# Altium schematic sheet [03] - 28PIN_SCHEMATIC.SchDoc("[03] - 28PIN_SCHEMATIC") (continued)
## Library data of the parts (continued)
R11 R12 = RC0805FR-071KL: SMD Chip Resistor, 1 kOhm, ± 1%, 125 mW, 0805 [2012 Metric], Thick Film, General Purpose; Manufacturer: Yageo; Max Power Dissipation: 125mW; Temperature Coefficient: 100ppm/°C; Value: 1kR; Voltage Rating: 150V; Case Code (Imperial): 0805; Case Code (Metric): 2012; Case/Package: 0805; Category: Chip SMD Resistors; Component Kind: Standard; ComponentLink1Description: https://datasheet.ciiva.com/7941/1686128-7941719.pdf?src-supplier=Element14; ComponentLink1URL: https://datasheet.ciiva.com/7941/1686128-7941719.pdf?src-supplier=Element14; ComponentLink2Description: https://datasheet.ciiva.com/46385/444164-46385020.pdf?src-supplier=Element14; ComponentLink2URL: https://datasheet.ciiva.com/46385/444164-46385020.pdf?src-supplier=Element14; ComponentLink3Description: https://datasheet.ciiva.com/2456/pyu-r-int-thick-7-2456976.pdf?src-supplier=Digikey; ComponentLink3URL: https://datasheet.ciiva.com/2456/pyu-r-int-thick-7-2456976.pdf?src-supplier=Digikey; ComponentLink4Description: https://datasheet.ciiva.com/2456/pyu-r-int-thick-7-2456977.pdf?src-supplier=Digikey; ComponentLink4URL: https://datasheet.ciiva.com/2456/pyu-r-int-thick-7-2456977.pdf?src-supplier=Digikey; ComponentLink5Description: https://datasheet.ciiva.com/2456/pyu-r-int-thick-7-2456975.pdf?src-supplier=Digikey; ComponentLink5URL: https://datasheet.ciiva.com/2456/pyu-r-int-thick-7-2456975.pdf?src-supplier=Digikey; ComponentLink6Description: https://datasheet.ciiva.com/16850/rc0805-16850409.pdf?src-supplier=Future+Electronics; ComponentLink6URL: https://datasheet.ciiva.com/16850/rc0805-16850409.pdf?src-supplier=Future+Electronics; Construction: Rectangular; Depth: 1.25mm; Features: Moisture Resistant; Height: 0.024inch; Lead Free: 5697LeadFree; Length: 0.079inch; Library Name: ATMEGA328P-PU.SchLib; Manufacturer Part Number: RC0805FR-071KL; Max Operating Temperature: 155°C; Military Standard: Not; Min Operating Temperature: -55°C; Number of Terminations: 2; Package Quantity: 1; Packaging: Tape and Reel; Pins: 2; Power Rating: 125mW; Pricing 1: 1=0.1, 10=0.025, 50=0.016, 100=0.0133, 500=0.00912, 1000=0.00787 (USD); Reach SVHC Compliant: No; Resistance Tolerance: 1%; Resistor Type: Thick Film; RoHS: Yes; RoHS Compliant: Yes; Series: RC; Stock 1: 3052484; Supplier 1: Digikey; Supplier Part Number 1: 311-1.00KCRCT-ND; Termination: SMD/SMT; Tolerance: 1%; Voltage Rating (AC): 150V; Voltage Rating (DC): 150V; Width: 0.049inch
R13 = R1K: SMD Chip Resistor, 1 kOhm, ± 1%, 125 mW, 0805 [2012 Metric], Thick Film, General Purpose; Manufacturer: Yageo; Manufacturer Part Number: RC0805FR-071KL; Max Power Dissipation: 125mW; Temperature Coefficient: 100ppm/°C; Value: 1kR; Voltage Rating: 150V; Case Code (Imperial): 0805; Case Code (Metric): 2012; Case/Package: 0805; Category: Chip SMD Resistors; Component Kind: Standard; ComponentLink1Description: https://datasheet.ciiva.com/7941/1686128-7941719.pdf?src-supplier=Element14; ComponentLink1URL: https://datasheet.ciiva.com/7941/1686128-7941719.pdf?src-supplier=Element14; ComponentLink2Description: https://datasheet.ciiva.com/46385/444164-46385020.pdf?src-supplier=Element14; ComponentLink2URL: https://datasheet.ciiva.com/46385/444164-46385020.pdf?src-supplier=Element14; ComponentLink3Description: https://datasheet.ciiva.com/2456/pyu-r-int-thick-7-2456976.pdf?src-supplier=Digikey; ComponentLink3URL: https://datasheet.ciiva.com/2456/pyu-r-int-thick-7-2456976.pdf?src-supplier=Digikey; ComponentLink4Description: https://datasheet.ciiva.com/2456/pyu-r-int-thick-7-2456977.pdf?src-supplier=Digikey; ComponentLink4URL: https://datasheet.ciiva.com/2456/pyu-r-int-thick-7-2456977.pdf?src-supplier=Digikey; ComponentLink5Description: https://datasheet.ciiva.com/2456/pyu-r-int-thick-7-2456975.pdf?src-supplier=Digikey; ComponentLink5URL: https://datasheet.ciiva.com/2456/pyu-r-int-thick-7-2456975.pdf?src-supplier=Digikey; ComponentLink6Description: https://datasheet.ciiva.com/16850/rc0805-16850409.pdf?src-supplier=Future+Electronics; ComponentLink6URL: https://datasheet.ciiva.com/16850/rc0805-16850409.pdf?src-supplier=Future+Electronics; Construction: Rectangular; Depth: 1.25mm; Features: Moisture Resistant; Height: 0.024inch; Lead Free: 5697LeadFree; Length: 0.079inch; Library Name: ATMEGA328P-PU.SchLib; Max Operating Temperature: 155°C; Military Standard: Not; Min Operating Temperature: -55°C; Number of Terminations: 2; Package Quantity: 1; Packaging: Tape and Reel; Pins: 2; Power Rating: 125mW; Pricing 1: 1=0.1, 10=0.025, 50=0.016, 100=0.0133, 500=0.00912, 1000=0.00787 (USD); Reach SVHC Compliant: No; Resistance Tolerance: 1%; Resistor Type: Thick Film; RoHS: Yes; RoHS Compliant: Yes; Series: RC; Stock 1: 3052484; Supplier 1: Digikey; Supplier Part Number 1: 311-1.00KCRCT-ND; Termination: SMD/SMT; Tolerance: 1%; Voltage Rating (AC): 150V; Voltage Rating (DC): 150V; Width: 0.049inch
SCKT_FOR_U1 = 28_DIP_SOCKET: Socket DIP 28Cnts DualWipe 0.3InSpc. PhosphorBronze Nickel KinkedTail 4800Series | 3M 4828-3004-CP; Category: Connectors; Component Kind: Standard; ComponentLink1Description: https://datasheet.ciiva.com/768/ts1099-768354.pdf?src-supplier=Digikey; ComponentLink1URL: https://datasheet.ciiva.com/768/ts1099-768354.pdf?src-supplier=Digikey; ComponentLink8Description: https://datasheet.ciiva.com/9480/m20-782-9480074.pdf?src-supplier=Avnet; ComponentLink8URL: https://datasheet.ciiva.com/9480/m20-782-9480074.pdf?src-supplier=Avnet; ComponentLink9Description: https://datasheet.ciiva.com/768/ts1099-768354.pdf?src-supplier=Digikey; ComponentLink9URL: https://datasheet.ciiva.com/768/ts1099-768354.pdf?src-supplier=Digikey; Library Name: ATMEGA328P-PU.SchLib; Manufacturer: 3M; Manufacturer Part Number: 4828-3004-CP; Pricing 1: 1=1.06, 14=0.89571, 28=0.85893, 56=0.84071, 112=0.80411, 252=0.73103, 504=0.65794, 1008=0.58483, 2506=0.53 (USD); RoHS: Yes; Stock 1: 11726; Supplier 1: Digikey
SW1 SW2 = Switch: Alcoswitch, Switch, Pushbutton, Tactile, SPST, 50mA, Thru Hole, 6mm | TE Connectivity FSM4JH; Manufacturer: TE Connectivity Alcoswitch; Actuator Length: 0.055inch; Actuator Material: PBT; Actuator Type: Standard; Illumination Type: Non-Illuminated,Illuminated; Insulation Resistance: 100MR; Max Voltage Rating (DC): 24V; Mechanical Life: 100000Cycles; Number of Positions: 2NUMBER; Operating Force: 160gf; Switch Function: Off-Mom; Switch Travel: 0.25mm; Category: Pushbutton Switches; Color: 46Colour; Component Kind: Standard; Contact Current Rating: 50mA; Contact Material: Copper Alloy; Contact Plating: Silver; Current Rating: 50mA; ELV: Compliant; Lead Free: Lead Free; Length: 5.99mm; Library Name: ATMEGA328P-PU.SchLib; Manufacturer Part Number: 1825910-6; Material: 4261ConductorMaterial; Max Current Rating: 50mA; Max Operating Temperature: 85°C; Min Operating Temperature: -35°C; Mounting Technology: Through Hole; Packaging: Loose Piece; Pricing 1: 1=0.13, 10=0.125, 25=0.1184, 100=0.1078, 250=0.10132, 500=0.09268, 1000=0.07759, 2500=0.07544, 5000=0.07113 (USD); Reach SVHC Compliant: Unknown; RoHS: Yes; RoHS Compliant: Yes; Series: FSMJ; Stock 1: 39160; Supplier 1: Digikey; Supplier Part Number 1: 450-1650-ND; Termination: PC Pins; Throw Configuration: SPST; Type: Switch; Width: 6mm
U1 = ATmega16P-PU_MUR: MCU 8-bit ATmega AVR RISC 16KB Flash 3.3V/5V 32-Pin QFN EP T/R - Tape and Reel; Manufacturer: Microchip; Manufacturer Part Number: ATMEGA16U2-MUR; Category: Microcontrollers; Component Kind: Standard; ComponentLink1Description: https://datasheet.ciiva.com/6653/7799s-6653670.pdf?src-supplier=Digikey; ComponentLink1URL: https://datasheet.ciiva.com/6653/7799s-6653670.pdf?src-supplier=Digikey; ComponentLink2Description: https://datasheet.ciiva.com/9234/doc7799-9234711.pdf?src-supplier=Avnet; ComponentLink2URL: https://datasheet.ciiva.com/9234/doc7799-9234711.pdf?src-supplier=Avnet; ComponentLink3Description: https://datasheet.ciiva.com/18626/doc7799-18626011.pdf?src-supplier=Digikey; ComponentLink3URL: https://datasheet.ciiva.com/18626/doc7799-18626011.pdf?src-supplier=Digikey; ComponentLink4Description: https://datasheet.ciiva.com/1556/7799s-1556476.pdf?src-supplier=Digikey; ComponentLink4URL: https://datasheet.ciiva.com/1556/7799s-1556476.pdf?src-supplier=Digikey; ComponentLink5Description: https://datasheet.ciiva.com/26207/doc7799-26207294.pdf?; ComponentLink5URL: https://datasheet.ciiva.com/26207/doc7799-26207294.pdf?; Library Name: ATMEGA16U2_MUR.SchLib; Pricing 1: 1=3.34, 25=3.06, 100=2.75 (USD); RoHS: Yes; Stock 1: 4098; Supplier 1: Digikey
U2 = 3.3_LDO_regulator: LDO Regulator Pos 3.3V 1.5A 6-Pin(5+Tab) SOT-223 T/R; Manufacturer: Texas Instruments; Manufacturer Part Number: TL1963A-33DCQR; Accuracy: 3%; Dropout Voltage: 340mV; Input Bias Current: 1mA; Max Supply Voltage: 20V; Min Current Limit: 1.6A; Min Input Voltage: 2.1V; Number of Outputs: 1; Output Type: Fixed; Polarity: Positive; Case/Package: SOT-223-6; Category: Voltage Regulators - Linear; Component Kind: Standard; ComponentLink1Description: https://datasheet.ciiva.com/2046/tl1963a-2046162.pdf?src-supplier=Digikey; ComponentLink1URL: https://datasheet.ciiva.com/2046/tl1963a-2046162.pdf?src-supplier=Digikey; ComponentLink2Description: https://datasheet.ciiva.com/2046/tl1963a-2046161.pdf?src-supplier=Digikey; ComponentLink2URL: https://datasheet.ciiva.com/2046/tl1963a-2046161.pdf?src-supplier=Digikey; ComponentLink3Description: https://datasheet.ciiva.com/2046/tl1963a-2046163.pdf?src-supplier=Digikey; ComponentLink3URL: https://datasheet.ciiva.com/2046/tl1963a-2046163.pdf?src-supplier=Digikey; Lead Free: Lead Free; Library Name: ATMEGA328P-PU.SchLib; Max Operating Temperature: 125°C; Max Output current: 1.5A; Max Output Voltage: 3.3V; Min Operating Temperature: -40°C; Min Output Voltage: 3.3V; Number of Regulators: 1; Output Current: 1.5A; Output Voltage: 3.3V; Package Quantity: 1; Packaging: Tape and Reel; Pins: 6; Pricing 1: 1=3.45, 10=3.098, 25=2.9292, 100=2.5387, 250=2.40848, 500=2.16114, 1000=1.82265 (USD); Quiescent Current: 1mA; Radiation Hardening: No; RoHS: Yes; RoHS Compliant: Yes; Series: -; Stock 1: 9273; Supplier 1: Digikey; Supplier Part Number 1: 296-24534-1-ND
U3 U5-U7 = AND_Gate: 1-ch, 2-input 2-V to 5.5-V high-speed (9 ns) AND gate with open-drain outputs 5-SOT-23 -55 to 125; Manufacturer: Texas Instruments; Low Level Output Current: 8mA; Max Supply Voltage: 5.5V; Min Supply Voltage: 2V; Nominal Supply Current: 1uA; Number of Bits: 1; Number of Channels: 1NUMBER; Number of Inputs: 2; Output Current: 25mA; Propagation Delay: 7.5ns; Case/Package: SOT-23; Category: Logic Gates; Component Kind: Standard; ComponentLink1Description: https://datasheet.ciiva.com/1864/sn74ahc1g09-1864411.pdf?src-supplier=Digikey; ComponentLink1URL: https://datasheet.ciiva.com/1864/sn74ahc1g09-1864411.pdf?src-supplier=Digikey; ComponentLink2Description: https://datasheet.ciiva.com/1864/sn74ahc1g09-1864410.pdf?src-supplier=Digikey; ComponentLink2URL: https://datasheet.ciiva.com/1864/sn74ahc1g09-1864410.pdf?src-supplier=Digikey; ComponentLink3Description: https://datasheet.ciiva.com/1864/sn74ahc1g09-1864412.pdf?src-supplier=Digikey; ComponentLink3URL: https://datasheet.ciiva.com/1864/sn74ahc1g09-1864412.pdf?src-supplier=Digikey; Height: 1.45mm; Lead Free: Lead Free; Length: 2.9mm; Library Name: ATMEGA328P-PU.SchLib; Logic Function: AND GATE; Manufacturer Part Number: SN74AHC1G09DBVR; Max Operating Temperature: 125°C; Min Operating Temperature: -55°C; Mounting Technology: Surface Mount; Number of Circuits: 1; Number of Elements: 1; Number of Gates: 1; Number of Outputs: 1; Output Voltage: 5.5V; Package Quantity: 3000; Packaging: Tape and Reel; Pins: 5; Pricing 1: 1=0.36, 10=0.269, 25=0.2352, 100=0.1522, 250=0.126, 500=0.1008, 1000=0.07729 (USD); Quiescent Current: 1uA; Radiation Hardening: No; Reach SVHC Compliant: No; RoHS: Yes; RoHS Compliant: Yes; Series: 74AHC; Stock 1: 8381; Supplier 1: Digikey; Technology: CMOS; Thickness: 1.2mm; Width: 1.6mm
U4 = ATMEGA328P-PU: Microchip - ATMEGA328P-PU - Microcontroller Mcu, 8 Bit, Atmega, 20MHZ, DIP-28; Core Architecture: AVR; Data Bus Width: 8b; Interface: I2C,SPI,USART,UART,2-Wire; Max Supply Voltage: 5.5V; Memory Format: FLASH; Memory Size: 32KB; Min Supply Voltage: 1.8V; Number of ADC Channels: 6; Number of I2C Channels: 1; Number of I/Os: 23; Number of Timers/Counters: 3; Oscillator Type: Internal; Peripherals: Brown-out Detect/Reset,POR,PWM,WDT; RAM Size: 2KB; Case/Package: PDIP; Category: Microcontrollers; Clock Frequency: 20MHz; Component Kind: Standard; ComponentLink1Description: https://datasheet.ciiva.com/19457/2139111-19457070.pdf?src-supplier=Jameco; ComponentLink1URL: https://datasheet.ciiva.com/19457/2139111-19457070.pdf?src-supplier=Jameco; ComponentLink2Description: https://datasheet.ciiva.com/1157/1684409-1157696.pdf?src-supplier=Element14; ComponentLink2URL: https://datasheet.ciiva.com/1157/1684409-1157696.pdf?src-supplier=Element14; ComponentLink3Description: https://datasheet.ciiva.com/29119/155197-da-01-en-microcontr-atmega328p-pu-8bit-pdip-28-29119593.pdf?src-supplier=Conrad; ComponentLink3URL: https://datasheet.ciiva.com/29119/155197-da-01-en-microcontr-atmega328p-pu-8bit-pdip-28-29119593.pdf?src-supplier=Conrad; ComponentLink4Description: https://datasheet.ciiva.com/15213/getdatasheetpartid-318533-15213133.pdf?src-supplier=Verical; ComponentLink4URL: https://datasheet.ciiva.com/15213/getdatasheetpartid-318533-15213133.pdf?src-supplier=Verical; Frequency: 20MHz; Height: 4.57mm; Lead Free: 5697LeadFree; Length: 34.8mm; Library Name: ATMEGA328P-PU.SchLib; Manufacturer: Microchip; Manufacturer Part Number: ATMEGA328P-PU; Max Operating Temperature: 85°C; Min Operating Temperature: -40°C; Mounting Technology: Through Hole; Number of PWM Channels: 6; Number of SPI Channels: 1; Package Quantity: 14; Packaging: Rail/Tube; Pins: 28; Pricing 1: 1=2.89, 25=2.65, 100=2.39 (USD); Radiation Hardening: No; Reach SVHC Compliant: No; RoHS: Yes; RoHS Compliant: Yes; Series: ATmega328P; Stock 1: 86839; Supplier 1: Digikey; Weight: 0.077603oz; Width: 7.49mm
Y1 = Crystal_8MHz: Crystal 8MHz ±20ppm (Tol) ±30ppm (Stability) 18pF FUND 100Ohm 2-Pin Mini-CSMD T/R; Manufacturer: Abracon; Category: Crystals; Component Kind: Standard; ComponentLink1Description: https://datasheet.ciiva.com/15116/getdatasheetpartid-722403-15116844.pdf?src-supplier=Verical; ComponentLink1URL: https://datasheet.ciiva.com/15116/getdatasheetpartid-722403-15116844.pdf?src-supplier=Verical; ComponentLink2Description: https://datasheet.ciiva.com/1357/1718755-1357277.pdf?src-supplier=Element14; ComponentLink2URL: https://datasheet.ciiva.com/1357/1718755-1357277.pdf?src-supplier=Element14; ComponentLink3Description: https://datasheet.ciiva.com/16416/abm7-16416924.pdf?src-supplier=Future+Electronics; ComponentLink3URL: https://datasheet.ciiva.com/16416/abm7-16416924.pdf?src-supplier=Future+Electronics; ComponentLink4Description: https://datasheet.ciiva.com/4944/abm7-19096-4944987.pdf?src-supplier=Mouser; ComponentLink4URL: https://datasheet.ciiva.com/4944/abm7-19096-4944987.pdf?src-supplier=Mouser; ComponentLink5Description: https://datasheet.ciiva.com/29998/abm7-29998171.pdf?src-supplier=Avnet; ComponentLink5URL: https://datasheet.ciiva.com/29998/abm7-29998171.pdf?src-supplier=Avnet; ComponentLink6Description: https://datasheet.ciiva.com/26941/getdatasheetpartid-719818-26941724.pdf?src-supplier=Verical; ComponentLink6URL: https://datasheet.ciiva.com/26941/getdatasheetpartid-719818-26941724.pdf?src-supplier=Verical; ComponentLink7Description: https://datasheet.ciiva.com/18649/abm7-18649865.pdf?src-supplier=Digikey; ComponentLink7URL: https://datasheet.ciiva.com/18649/abm7-18649865.pdf?src-supplier=Digikey; ComponentLink8Description: https://datasheet.ciiva.com/18649/abm7-18649866.pdf?src-supplier=Digikey; ComponentLink8URL: https://datasheet.ciiva.com/18649/abm7-18649866.pdf?src-supplier=Digikey; ComponentLink9Description: https://datasheet.ciiva.com/18649/abm7-18649867.pdf?src-supplier=Digikey; ComponentLink9URL: https://datasheet.ciiva.com/18649/abm7-18649867.pdf?src-supplier=Digikey; ComponentLink10Description: https://datasheet.ciiva.com/5788/abm7-5788054.pdf?src-supplier=Farnell; ComponentLink10URL: https://datasheet.ciiva.com/5788/abm7-5788054.pdf?src-supplier=Farnell; Library Name: ATMEGA328P-PU.SchLib; Manufacturer Part Number: ABM7-8.000MHZ-D2Y-T; Pricing 1: 1=0.67, 10=0.556, 50=0.5, 100=0.4444, 500=0.42218 (USD); RoHS: Yes; Stock 1: 54410; Supplier 1: Digikey; Supplier Part Number 1: 535-9831-1-ND; Lead Free: 5697LeadFree
Y2 = Crystal_10MHz: Crystal 10MHz ±20ppm (Tol) ±30ppm (Stability) 18pF FUND 60Ohm 2-Pin Mini-CSMD T/R; Manufacturer: Abracon; Category: Crystals; Component Kind: Standard; ComponentLink1Description: https://datasheet.ciiva.com/29998/abm3-29998222.pdf?src-supplier=Avnet; ComponentLink1URL: https://datasheet.ciiva.com/29998/abm3-29998222.pdf?src-supplier=Avnet; ComponentLink2Description: https://datasheet.ciiva.com/16383/abm7-16383174.pdf?src-supplier=Future+Electronics; ComponentLink2URL: https://datasheet.ciiva.com/16383/abm7-16383174.pdf?src-supplier=Future+Electronics; ComponentLink3Description: https://datasheet.ciiva.com/7998/947971-7998600.pdf?src-supplier=Element14; ComponentLink3URL: https://datasheet.ciiva.com/7998/947971-7998600.pdf?src-supplier=Element14; ComponentLink4Description: https://datasheet.ciiva.com/46385/947971-46385243.pdf?src-supplier=Element14; ComponentLink4URL: https://datasheet.ciiva.com/46385/947971-46385243.pdf?src-supplier=Element14; ComponentLink5Description: https://datasheet.ciiva.com/22658/947971-22658293.pdf?src-supplier=Element14; ComponentLink5URL: https://datasheet.ciiva.com/22658/947971-22658293.pdf?src-supplier=Element14; ComponentLink6Description: https://datasheet.ciiva.com/26941/getdatasheetpartid-719818-26941724.pdf?src-supplier=Verical; ComponentLink6URL: https://datasheet.ciiva.com/26941/getdatasheetpartid-719818-26941724.pdf?src-supplier=Verical; ComponentLink7Description: https://datasheet.ciiva.com/18649/abm7-18649865.pdf?src-supplier=Digikey; ComponentLink7URL: https://datasheet.ciiva.com/18649/abm7-18649865.pdf?src-supplier=Digikey; ComponentLink8Description: https://datasheet.ciiva.com/18649/abm7-18649866.pdf?src-supplier=Digikey; ComponentLink8URL: https://datasheet.ciiva.com/18649/abm7-18649866.pdf?src-supplier=Digikey; ComponentLink9Description: https://datasheet.ciiva.com/18649/abm7-18649867.pdf?src-supplier=Digikey; ComponentLink9URL: https://datasheet.ciiva.com/18649/abm7-18649867.pdf?src-supplier=Digikey; ComponentLink10Description: https://datasheet.ciiva.com/5788/abm7-5788054.pdf?src-supplier=Farnell; ComponentLink10URL: https://datasheet.ciiva.com/5788/abm7-5788054.pdf?src-supplier=Farnell; Library Name: ATMEGA328P-PU.SchLib; Manufacturer Part Number: ABM3-10.000MHZ-D2Y-T; Pricing 1: 1=0.58, 10=0.48, 50=0.4318, 100=0.3838, 500=0.3646 (USD); RoHS: Yes; Stock 1: 23765; Supplier 1: Digikey; Supplier Part Number 1: 535-10631-1-ND
Z1 Z2 = Varistor: ESD Suppressor Multilayer Varistor 5V Automotive 2-Pin Case 0603(1608Metric) T/R; Manufacturer: Bourns; Clamping Voltage: 20V; Current Rating: 50nA; Number of Channels: 1; Value: 0.5pF; Voltage Rating (AC): 8V; Case Code (Imperial): 0603; Case Code (Metric): 1608; Case/Package: 0603; Category: Varistors; Component Kind: Standard; ComponentLink1Description: https://datasheet.ciiva.com/8084/mlc-8084047.pdf?src-supplier=Avnet; ComponentLink1URL: https://datasheet.ciiva.com/8084/mlc-8084047.pdf?src-supplier=Avnet; ComponentLink2Description: https://datasheet.ciiva.com/6991/mlc-6991834.pdf?src-supplier=Digikey; ComponentLink2URL: https://datasheet.ciiva.com/6991/mlc-6991834.pdf?src-supplier=Digikey; ComponentLink3Description: https://datasheet.ciiva.com/6991/mlc-6991836.pdf?src-supplier=Digikey; ComponentLink3URL: https://datasheet.ciiva.com/6991/mlc-6991836.pdf?src-supplier=Digikey; Contact Plating: Tin; Depth: 0.8mm; Leakage Current: 50nA; Length: 1.6mm; Library Name: ATMEGA328P-PU.SchLib; Manufacturer Part Number: CG0603MLC-05E; Max Operating Temperature: 85°C; Max Voltage Rating (DC): 5V; Min Operating Temperature: -40°C; Number of Circuits: 1; Operating Supply Voltage: 5V; Package Quantity: 1; Packaging: Tape and Reel; Pins: 2; Pricing 1: 1=0.45, 10=0.336, 25=0.3032, 100=0.2096, 250=0.17648, 500=0.14338, 1000=0.11029, 2500=0.09926 (USD); Radiation Hardening: No; Reach SVHC Compliant: No; RoHS: Yes; RoHS Compliant: Yes; Series: MLC; Stock 1: 153475; Supplier 1: Digikey; Supplier Part Number 1: CG0603MLC-05ECT-ND; Termination: SMD/SMT; Thickness: 0.8mm; Voltage: 5V; Voltage Rating (DC): 5V; Width: 0.8mm; Working Voltage: 5V
